# Altium schematic sheet RC96_FP.SchDoc
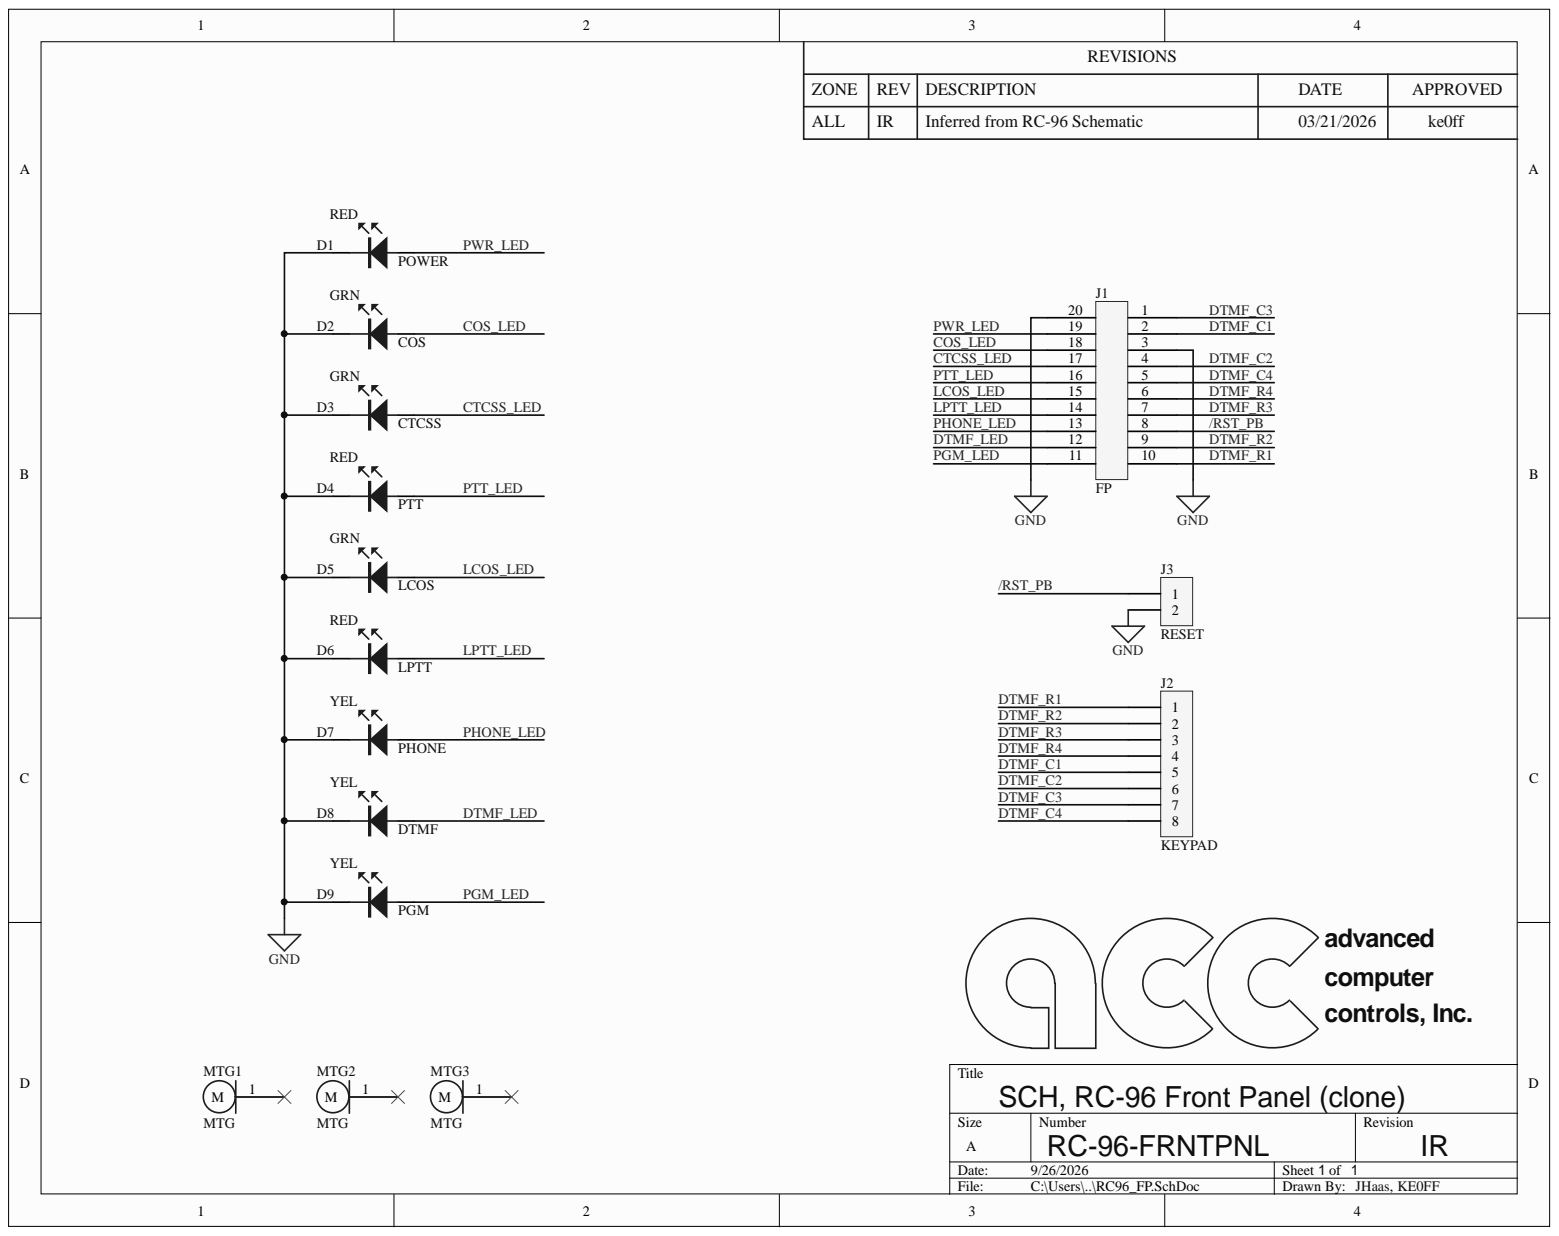
[diagram p0: RC96_FP.SchDoc]

## Parts
D1  POWER  NOTES: RED  [LED2-TH]
D2  COS  NOTES: GRN  [LED2-TH]
D3  CTCSS  NOTES: GRN  [LED2-TH]
D4  PTT  NOTES: RED  [LED2-TH]
D5  LCOS  NOTES: GRN  [LED2-TH]
D6  LPTT  NOTES: RED  [LED2-TH]
D7  PHONE  NOTES: YEL  [LED2-TH]
D8  DTMF  NOTES: YEL  [LED2-TH]
D9  PGM  NOTES: YEL  [LED2-TH]
J1  FP  [HDR20]
J2  KEYPAD  [MOLEX-08]
J3  RESET  [MOLEX-02]
MTG1  MTG  [MTG_HOLE_0.250_0.125]
MTG2  MTG  [MTG_HOLE_0.250_0.125]
MTG3  MTG  [MTG_HOLE_0.250_0.125]
  ACC_LOGO  [ACC_LOGO]  (value not drawn)  (no BOM)

## Nets
GND: D1.1 D2.1 D3.1 D4.1 D5.1 D6.1 D7.1 D8.1 D9.1 J1.3 J1.20 J3.2
/RST_PB: J1.8 J3.1
COS_LED: D2.2 J1.18
CTCSS_LED: D3.2 J1.17
DTMF_C1: J1.2 J2.5
DTMF_C2: J1.4 J2.6
DTMF_C3: J1.1 J2.7
DTMF_C4: J1.5 J2.8
DTMF_LED: D8.2 J1.12
DTMF_R1: J1.10 J2.1
DTMF_R2: J1.9 J2.2
DTMF_R3: J1.7 J2.3
DTMF_R4: J1.6 J2.4
LCOS_LED: D5.2 J1.15
LPTT_LED: D6.2 J1.14
PGM_LED: D9.2 J1.11
PHONE_LED: D7.2 J1.13
PTT_LED: D4.2 J1.16
PWR_LED: D1.2 J1.19

Not connected: MTG1 1; MTG2 1; MTG3 1

## Library data of the parts
D1-D9 = LED: LED, SMD; ALT-MPN: -; Component Kind: Standard; DNP: -; MFR: -; MPN: -; NOTES2: -; PRI-MPN: -; Library Name: Galaxy SCH-jem-1.08.SchLib
J1 = CON_20P_DIP: CON, DIP, 20 POS, 0.1" LS; ALT-MPN: -; Component Kind: Standard; DNP: -; MFR: -; MPN: -; NOTES: -; NOTES2: -; PRI-MPN: -; Library Name: Galaxy SCH-jem-1.08.SchLib
J2 = CON8: Connector, 8 pin; ALT-MPN: -; Component Kind: Standard; DNP: -; MFR: -; MPN: -; NOTES: -; NOTES2: -; PRI-MPN: -; Library Name: Galaxy SCH-jem-1.08.SchLib
J3 = CON2: Connector, 2 pin; ALT-MPN: -; Component Kind: Standard; DNP: -; MFR: -; MPN: -; NOTES: -; NOTES2: -; PRI-MPN: -; Library Name: Galaxy SCH-jem-1.08.SchLib
MTG1-MTG3 = MTG: MECH, MTG HOLE; ALT-MPN: -; Component Kind: Standard; DNP: -; MFR: -; MPN: -; NOTES: -; NOTES2: -; PRI-MPN: -; Library Name: Galaxy SCH-jem-1.08.SchLib
